# Altium schematic sheet 07_I2C_Translator.SchDoc(07_I2C_Translator)
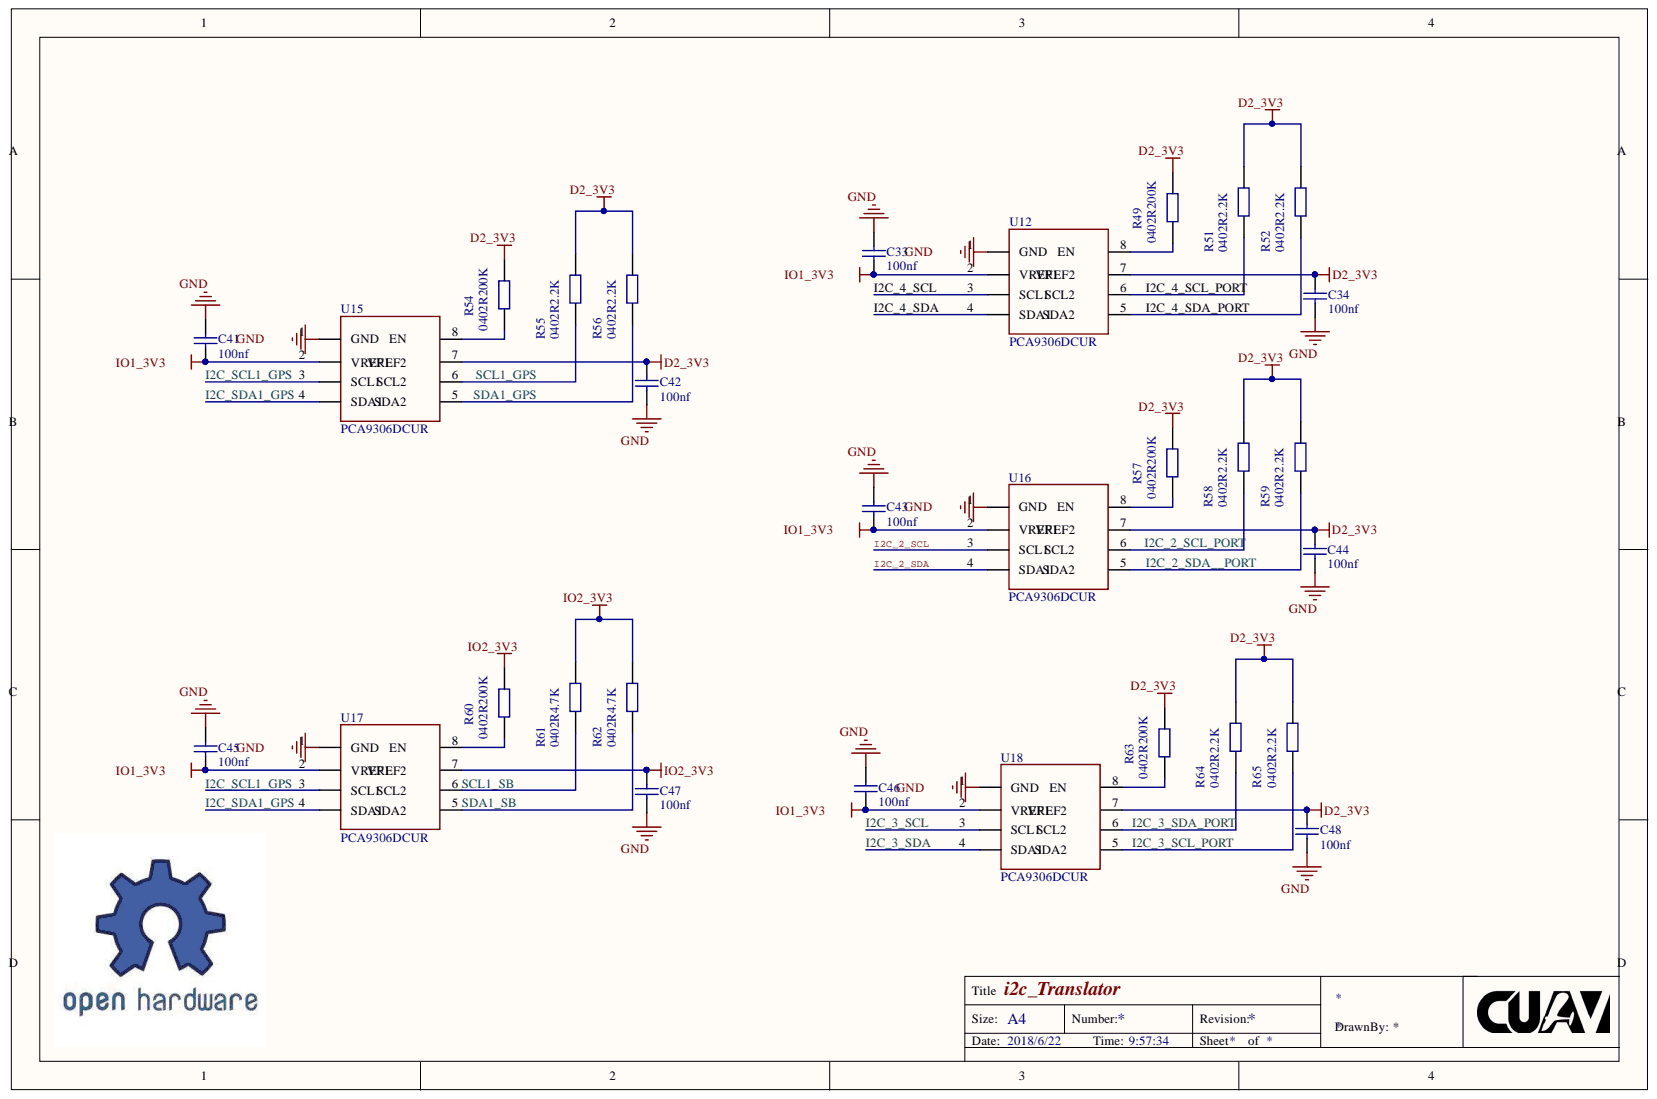
[diagram p0: 07_I2C_Translator.SchDoc]

## Parts
C33  100nf  [0402CAP]
C34  100nf  [0402CAP]
C41  100nf  [0402CAP]
C42  100nf  [0402CAP]
C43  100nf  [0402CAP]
C44  100nf  [0402CAP]
C45  100nf  [0402CAP]
C46  100nf  [0402CAP]
C47  100nf  [0402CAP]
C48  100nf  [0402CAP]
R49  0402R200K  [0402R]
R51  0402R2.2K  [0402R]
R52  0402R2.2K  [0402R]
R54  0402R200K  [0402R]
R55  0402R2.2K  [0402R]
R56  0402R2.2K  [0402R]
R57  0402R200K  [0402R]
R58  0402R2.2K  [0402R]
R59  0402R2.2K  [0402R]
R60  0402R200K  [0402R]
R61  0402R4.7K  [0402R]
R62  0402R4.7K  [0402R]
R63  0402R200K  [0402R]
R64  0402R2.2K  [0402R]
R65  0402R2.2K  [0402R]
U12  PCA9306DCUR  [VSSOP (8)]
U15  PCA9306DCUR  [VSSOP (8)]
U16  PCA9306DCUR  [VSSOP (8)]
U17  PCA9306DCUR  [VSSOP (8)]
U18  PCA9306DCUR  [VSSOP (8)]

## Nets
D2_3V3: C34.2 C42.2 C44.2 C48.2 R49.2 R51.2 R52.2 R54.2 R55.2 R56.2 R57.2 R58.2 R59.2 R63.2 R64.2 R65.2 U12.7 U15.7 U16.7 U18.7
GND: C33.1 C34.1 C41.1 C42.1 C43.1 C44.1 C45.1 C46.1 C47.1 C48.1 U12.1(GND) U15.1(GND) U16.1(GND) U17.1(GND) U18.1(GND)
IO1_3V3: C33.2 C41.2 C43.2 C45.2 C46.2 U12.2(VREF1) U15.2(VREF1) U16.2(VREF1) U17.2(VREF1) U18.2(VREF1)
IO2_3V3: C47.2 R60.2 R61.2 R62.2 U17.7
I2C_2_SCL: U16.3(SCL1)
I2C_2_SCL_PORT: R58.1 U16.6
I2C_2_SDA: U16.4(SDA1)
I2C_2_SDA__PORT: R59.1 U16.5
I2C_3_SCL: U18.3(SCL1)
I2C_3_SCL_PORT: R65.1 U18.5
I2C_3_SDA: U18.4(SDA1)
I2C_3_SDA_PORT: R64.1 U18.6
I2C_4_SCL: U12.3(SCL1)
I2C_4_SCL_PORT: R51.1 U12.6
I2C_4_SDA: U12.4(SDA1)
I2C_4_SDA_PORT: R52.1 U12.5
I2C_SCL1_GPS: U15.3(SCL1) U17.3(SCL1)
I2C_SDA1_GPS: U15.4(SDA1) U17.4(SDA1)
SCL1_GPS: R55.1 U15.6
SCL1_SB: R61.1 U17.6
SDA1_GPS: R56.1 U15.5
SDA1_SB: R62.1 U17.5
NetR49_1: R49.1 U12.8
NetR54_1: R54.1 U15.8
NetR57_1: R57.1 U16.8
NetR60_1: R60.1 U17.8
NetR63_1: R63.1 U18.8

## Library data of the parts
C33 C34 C41-C47 = Cap: 0402 100nf 50V 10%; Component Kind: Standard; Library Name: Miscellaneous Devices.IntLib; Signal Integrity: Cap; Simulation: CAP
C48 = Cap: Component Kind: Standard; Library Name: Miscellaneous Devices.IntLib; Signal Integrity: Cap; Simulation: CAP
R49 R54 R57 R60 R63 = 0402R1K: 0402R200K 1%; Component Kind: Standard; Library Name: CUAV.SchLib
R51 R52 R55 R56 R58 R59 R64 R65 = 0402R1K: 0402R2.2K 1%; Component Kind: Standard; Library Name: CUAV.SchLib
R61 R62 = 0402R1K: 0402R4.7K 1%; Component Kind: Standard; Library Name: CUAV.SchLib
U12 U15-U18 = PCA9306DCUR: Component Kind: Standard; Library Name: CUAV.SchLib
